# Altium schematic sheet cwh_frontend.SchDoc
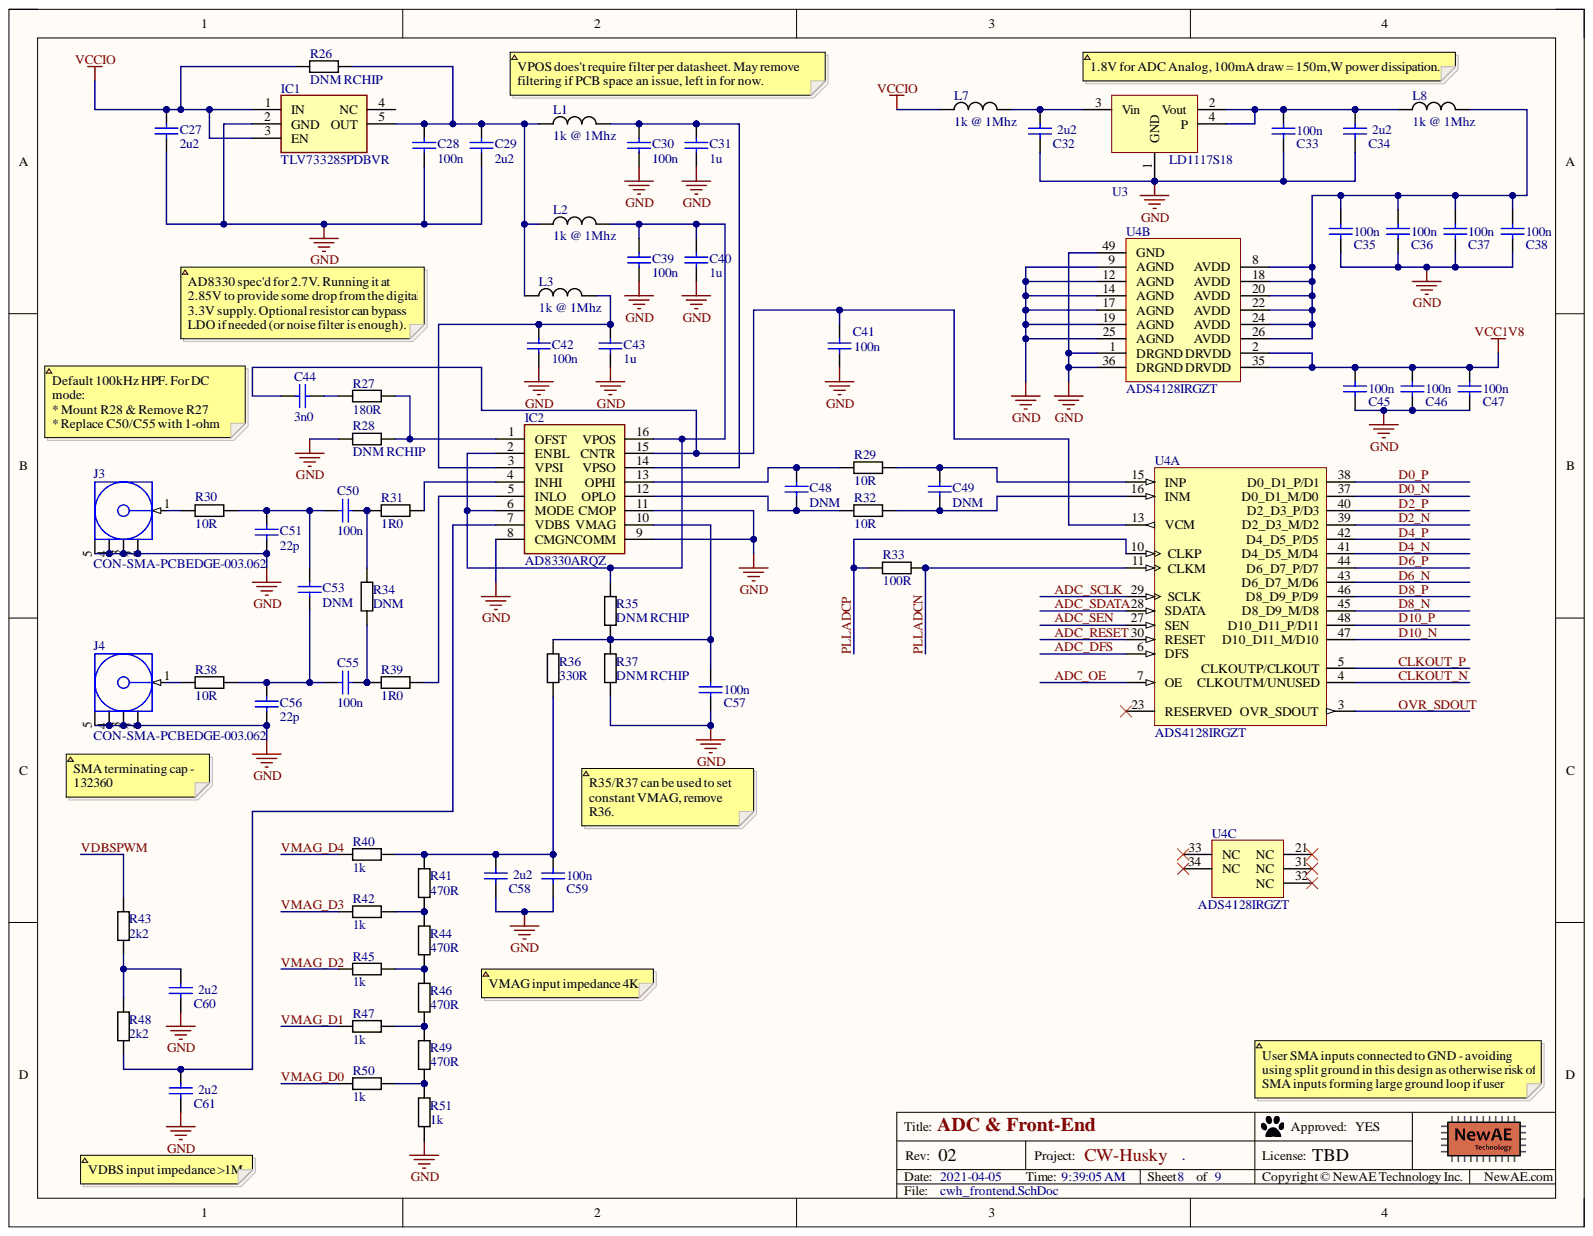
[diagram p0: cwh_frontend.SchDoc]

## Parts
C27  2u2  [0603]
C28  100n  [0402]
C29  2u2  [0603]
C30  100n  [0402]
C31  1u  [0603]
C32  2u2  [0603]
C33  100n  [0402]
C34  2u2  [0603]
C35  100n  [0402]
C36  100n  [0402]
C37  100n  [0402]
C38  100n  [0402]
C39  100n  [0402]
C40  1u  [0603]
C41  100n  [0402]
C42  100n  [0402]
C43  1u  [0603]
C44  3n0  [0603]
C45  100n  [0402]
C46  100n  [0402]
C47  100n  [0402]
C48  DNM  [0402]
C49  DNM  [0402]
C50  100n  [0402]
C51  22p  [0603]
C53  DNM  [0603]
C55  100n  [0402]
C56  22p  [0603]
C57  100n  [0402]
C58  2u2  [0603]
C59  100n  [0402]
C60  2u2  [0603]
C61  2u2  [0603]
IC1  TLV733285PDBVR  [SOT95P280X145-5N]
IC2  AD8330ARQZ  [SOP64P600X175-16N]
J3  CON-SMA-PCBEDGE-003.062  [CON-SMAM-PCB-EDGE062]
J4  CON-SMA-PCBEDGE-003.062  [CON-SMAM-PCB-EDGE062]
L1  1k @ 1Mhz  [0603]
L2  1k @ 1Mhz  [0603]
L3  1k @ 1Mhz  [0603]
L7  1k @ 1Mhz  [0603]
L8  1k @ 1Mhz  [0603]
R26  DNM RCHIP  [0603]
R27  180R  [0603]
R28  DNM RCHIP  [0603]
R29  10R  [0402]
R30  10R  [0603]
R31  1R0  [0402]
R32  10R  [0402]
R33  100R  [0402]
R34  DNM  [0402]
R35  DNM RCHIP  [0603]
R36  330R  [0603]
R37  DNM RCHIP  [0603]
R38  10R  [0603]
R39  1R0  [0402]
R40  1k  [0402]
R41  470R  [0402]
R42  1k  [0402]
R43  2k2  [0402]
R44  470R  [0402]
R45  1k  [0402]
R46  470R  [0402]
R47  1k  [0402]
R48  2k2  [0402]
R49  470R  [0402]
R50  1k  [0402]
R51  1k  [0402]
U3  LD1117S18  [SOT-223]
U4  ADS4128IRGZT  [TI-RGZ48-5600X5600TP_V]  (parts A,B,C)

## Nets
GND: C27.2 C28.2 C29.2 C30.2 C31.2 C32.2 C33.2 C34.2 C35.2 C36.2 C37.2 C38.2 C39.2 C40.2 C41.2 C42.2 C43.2 C45.2 C46.2 C47.2 C51.2 C56.2 C57.2 C58.2 C59.2 C60.2 C61.2 IC1.2(GND) IC2.8(CMGN) IC2.9(COMM) IC2.11(CMOP) J3.2 J3.3 J3.4 J3.5 J4.2 J4.3 J4.4 J4.5 R28.1 R37.1 R51.1 U3.1(GND) U4.1(DRGND) U4.9(AGND) U4.12(AGND) U4.14(AGND) U4.17(AGND) U4.19(AGND) U4.25(AGND) U4.36(DRGND) U4.49(GND)
VCC1V8: C45.1 C46.1 C47.1 U4.2(DRVDD) U4.35(DRVDD)
VCCIO: C27.1 IC1.1(IN) IC1.3(EN) L7.1 R26.1
ADC_DFS: U4.6(DFS)
ADC_OE: U4.7(OE)
ADC_RESET: U4.30(RESET)
ADC_SCLK: U4.29(SCLK)
ADC_SDATA: U4.28(SDATA)
ADC_SEN: U4.27(SEN)
CLKOUT_N: U4.4(CLKOUTM/UNUSED)
CLKOUT_P: U4.5(CLKOUTP/CLKOUT)
D0_N: U4.37(D0_D1_M/D0)
D0_P: U4.38(D0_D1_P/D1)
D2_N: U4.39(D2_D3_M/D2)
D2_P: U4.40(D2_D3_P/D3)
D4_N: U4.41(D4_D5_M/D4)
D4_P: U4.42(D4_D5_P/D5)
D6_N: U4.43(D6_D7_M/D6)
D6_P: U4.44(D6_D7_P/D7)
D8_N: U4.45(D8_D9_M/D8)
D8_P: U4.46(D8_D9_P/D9)
D10_N: U4.47(D10_D11_M/D10)
D10_P: U4.48(D10_D11_P/D11)
OVR_SDOUT: U4.3(OVR_SDOUT)
PLLADCN: R33.2 U4.11(CLKM)
PLLADCP: R33.1 U4.10(CLKP)
VDBSPWM: R43.1
VMAG_D0: R50.1
VMAG_D1: R47.1
VMAG_D2: R45.1
VMAG_D3: R42.1
VMAG_D4: R40.1
NetC28_1: C28.1 C29.1 IC1.5(OUT) L1.1 L2.1 L3.1 R26.2
NetC30_1: C30.1 C31.1 IC2.14(VPSO) L1.2
NetC32_1: C32.1 L7.2 U3.3(Vin)
NetC33_1: C33.1 C34.1 L8.1 U3.2(Vout) U3.4(P)
NetC35_1: C35.1 C36.1 C37.1 C38.1 L8.2 U4.8(AVDD) U4.18(AVDD) U4.20(AVDD) U4.22(AVDD) U4.24(AVDD) U4.26(AVDD)
NetC39_1: C39.1 C40.1 IC2.2(ENBL) IC2.6(MODE) IC2.16(VPOS) L2.2 R35.2
NetC41_1: C41.1 C44.1 IC2.15(CNTR) U4.13(VCM)
NetC42_1: C42.1 C43.1 IC2.3(VPSI) L3.2
NetC44_2: C44.2 R27.1
NetC48_1: C48.1 IC2.13(OPHI) R29.1
NetC48_2: C48.2 IC2.12(OPLO) R32.1
NetC49_1: C49.1 R29.2 U4.15(INP)
NetC49_2: C49.2 R32.2 U4.16(INM)
NetC50_1: C50.1 C51.1 C53.1 R30.2
NetC50_2: C50.2 R31.1 R34.2
NetC53_2: C53.2 C55.1 C56.1 R38.2
NetC55_2: C55.2 R34.1 R39.1
NetC57_1: C57.1 IC2.10(VMAG) R35.1 R36.2 R37.2
NetC58_1: C58.1 C59.1 R36.1 R40.2 R41.2
NetC60_1: C60.1 R43.2 R48.1
NetC61_1: C61.1 IC2.7(VDBS) R48.2
NetIC2_1: IC2.1(OFST) R27.2 R28.2
NetIC2_4: IC2.4(INHI) R31.2
NetIC2_5: IC2.5(INLO) R39.2
NetJ3_1: J3.1 R30.1
NetJ4_1: J4.1 R38.1
NetR41_1: R41.1 R42.2 R44.2
NetR44_1: R44.1 R45.2 R46.2
NetR46_1: R46.1 R47.2 R49.2
NetR49_1: R49.1 R50.2 R51.2

Not connected: IC1 4(NC); U4 21(NC) 23(RESERVED) 31(NC) 32(NC) 33(NC) 34(NC)

## Library data of the parts
C27 C29 C32 C34 C58 C60 C61 = CCHIP-2u2-0603: 2u2, 0603; Manufacture 1: Samsung; Manufacture Part Number 1: CL10B225KP8NNNC; Case-Metric: 1608; Component Group: Capacitor; Database Table Name: main; Rated Voltage: 10VDC; Case-EIA: 0603; Component Kind: Standard; Component Type: Standard; Library Name: NewAE_AltiumLib.DBLib; Mounting Technology: SMT; Part Number: CCHIP-2u2-0603; Pin Count: 2; Supplier 1: Digi-Key; Supplier Part Number 1: 1276-1134-2-ND; Value: 2u2
C28 C30 C33 C35-C39 C41 C42 C45-C47 C50 C55 C57 C59 = CCHIP-100n-0402: 100n, 0402; Manufacture Part Number 1: CGA2B3X7R1V104K050BB; Component Group: Capacitor; Database Table Name: main; Case-EIA: 0402; Component Kind: Standard; Component Type: Standard; Library Name: NewAE_AltiumLib.DBLib; Mounting Technology: SMT; Part Number: CCHIP-100n-0402; Pin Count: 2; Supplier 1: Digi-Key; Supplier Part Number 1: 445-6901-1-ND; Value: 100n
C31 C40 C43 = CCHIP-1u-0603: 1u, 0603, 50V; Manufacture Part Number 1: CL10A105KB8NNNC; Case-Metric: 1608; Component Group: Capacitor; Database Table Name: main; Rated Voltage: 50VDC; Case-EIA: 0603; Component Kind: Standard; Component Type: Standard; Library Name: NewAE_AltiumLib.DBLib; Mounting Technology: SMT; Part Number: CCHIP-1u-0603; Pin Count: 2; Supplier 1: Digi-Key; Supplier Part Number 1: 1276-1860-1-ND; Value: 1u
C44 = CCHIP-3n0-0603: 3n0, 0603; Case-Metric: 1608; Component Group: Capacitor; Database Table Name: main; Case-EIA: 0603; Component Kind: Standard; Component Type: Standard; Library Name: NewAE_AltiumLib.DBLib; Mounting Technology: SMT; Part Number: CCHIP-3n0-0603; Pin Count: 2; Value: 3n0
C48 C49 = CCHIP-DNM-0402: DNM, 0402; Component Group: Capacitor; Database Table Name: main; Case-EIA: 0402; Component Kind: Standard; Component Type: Standard; Library Name: NewAE_AltiumLib.DBLib; Mounting Technology: SMT; Part Number: CCHIP-DNM-0402; Pin Count: 2; Value: DNM
C51 C56 = CCHIP-22p-0603: 22p, 0603; Manufacture 1: Murata Electronics North America; Manufacture Part Number 1: GQM1885C1H220JB01D; Case-Metric: 1608; Component Group: Capacitor; Database Table Name: main; Rated Voltage: 50V; Case-EIA: 0603; Component Kind: Standard; Component Type: Standard; Library Name: NewAE_AltiumLib.DBLib; Mounting Technology: SMT; Part Number: CCHIP-22p-0603; Pin Count: 2; RoHS: Yes; Supplier 1: Digi-Key; Supplier Part Number 1: 490-3575-1-ND; Value: 22p
C53 = CCHIP-DNM-0603: DNM, 0603; Component Group: Capacitor; Database Table Name: main; Case-EIA: 0603; Component Kind: Standard; Component Type: Standard; Library Name: NewAE_AltiumLib.DBLib; Mounting Technology: SMT; Part Number: CCHIP-DNM-0603; Pin Count: 2; Value: DNM
IC1 = TLV733285PDBVR: Integrated Circuit; Manufacturer_Name: Texas Instruments; Arrow Part Number: TLV733285PDBVR; Arrow Price/Stock: https://www.arrow.com/en/products/tlv733285pdbvr/texas-instruments; Component Kind: Standard; Datasheet Link: http://www.ti.com/lit/gpn/tlv733p; Height: 1.45mm; Manufacturer_Part_Number: TLV733285PDBVR; Mouser Part Number: 595-TLV733285PDBVR; Mouser Price/Stock: https://www.mouser.com/Search/Refine.aspx?Keyword=595-TLV733285PDBVR
IC2 = AD8330ARQZ: Integrated Circuit; Manufacturer_Name: Analog Devices; Arrow Part Number: AD8330ARQZ; Arrow Price/Stock: https://www.arrow.com/en/products/ad8330arqz/analog-devices; Component Kind: Standard; Datasheet Link: http://componentsearchengine.com/Datasheets/3/AD8330ARQZ.pdf; Height: 1.75mm; Manufacturer_Part_Number: AD8330ARQZ; Mouser Part Number: 584-AD8330ARQZ; Mouser Price/Stock: https://www.mouser.co.uk/ProductDetail/Analog-Devices/AD8330ARQZ/?qs=%2FtpEQrCGXCy%252BhrhgNF8PFQ%3D%3D
J3 J4 = CON-SMA-PCBEDGE-003.062: SMA Female Edge Mount Connector for 0.062" Thick Board; Database Table Name: main; Component Group: Connector; Component Kind: Standard; Component Type: Standard; ComponentLink1Description: Manufacturer URL; ComponentLink1URL: http://www.linxtechnologies.com/; ComponentLink2Description: Datasheet; ComponentLink2URL: http://www.connectorcity.com/diagrams/SMA/CONSMA003.062.pdf; Library Name: NewAE_AltiumLib.DBLib; Part Number: CON-SMA-PCBEDGE-003.062; Pin Count: 5
L1-L3 L7 L8 = FBCHIP-1k-0603: Ferrite Beads HI CUR CHIP BD 0603 1000OHMS 25%; Manufacture 1: Taiyo Yuden; Manufacture Part Number 1: FBMH1608HM102-T FBMH1608HM102-T FBMH1608HM102-T FBMH1608HM102-T; Component Group: Inductor; Database Table Name: main; PP Material Stack: 0; Component Kind: Standard; Component Type: Standard; Library Name: NewAE_AltiumLib.DBLib; Mounting Technology: SMT; Part Number: FBCHIP-1k-0603; Pin Count: 2; PP Rotation: 0; RoHS: Yes; Supplier 1: Mouser; Supplier Part Number 1: 963-FBMH1608HM102-T
R26 R28 R35 R37 = RCHIP-DNM-0603: Do Not Mount Resistor; Case-Metric: 1608; Database Table Name: main; Case-EIA: 0603; Component Group: Resistor; Component Kind: Standard; Component Type: Standard; Library Name: NewAE_AltiumLib.DBLib; Mounting Technology: SMT; Part Number: RCHIP-DNM-0603; Pin Count: 2
R27 = RCHIP-180R-0603: 180R res, 0603, 1%, 1/10W; Manufacture 1: Yageo; Manufacture Part Number 1: RC0603FR-07180RL; Case-Metric: 1608; Component Group: Resistor; Database Table Name: main; Rated Power: 0.1W; Rated Voltage: 75VDC; Case-EIA: 0603; Component Kind: Standard; Component Type: Standard; Library Name: NewAE_AltiumLib.DBLib; Mounting Technology: SMT; Part Number: RCHIP-180R-0603; Pin Count: 2; Supplier 1: Digi-Key; Supplier Part Number 1: 311-180HRCT-ND; Temperature Range: -55C to 155C; Tolerance: 1%; Value: 180R
R29 R32 = RCHIP-10R-0402: 10R res, 0402, 1%, 1/16W; Manufacture 1: Yageo; Manufacture Part Number 1: RC0402FR-0710RL; Case-Metric: 1005; Component Group: Resistor; Database Table Name: main; Rated Power: 0.063W; Rated Voltage: 75VDC; Case-EIA: 0402; Component Kind: Standard; Component Type: Standard; Library Name: NewAE_AltiumLib.DBLib; Mounting Technology: SMT; Part Number: RCHIP-10R-0402; Pin Count: 2; Supplier 1: Digi-Key; Supplier Part Number 1: 311-10.0LRCT-ND; Temperature Range: -55C to 155C; Tolerance: 1%; Value: 10R
R30 R38 = RCHIP-10R-0603: 10R res, 0603, 1%, 1/10W; Manufacture 1: Yageo; Manufacture Part Number 1: RC0603FR-0710RL; Case-Metric: 1608; Component Group: Resistor; Database Table Name: main; Rated Power: 0.1W; Rated Voltage: 75VDC; Case-EIA: 0603; Component Kind: Standard; Component Type: Standard; Library Name: NewAE_AltiumLib.DBLib; Mounting Technology: SMT; Part Number: RCHIP-10R-0603; Pin Count: 2; Supplier 1: Digi-Key; Supplier Part Number 1: 311-10.0HRCT-ND; Temperature Range: -55C to 155C; Tolerance: 1%; Value: 10R
R31 R39 = RCHIP-1R0-0402: 1R0 res, 0402, 1%, 1/16W; Manufacture 1: Yageo; Manufacture Part Number 1: RC0402FR-071RL; Case-Metric: 1005; Component Group: Resistor; Database Table Name: main; Rated Power: 0.063W; Rated Voltage: 75VDC; Case-EIA: 0402; Component Kind: Standard; Component Type: Standard; Library Name: NewAE_AltiumLib.DBLib; Mounting Technology: SMT; Part Number: RCHIP-1R0-0402; Pin Count: 2; Supplier 1: Digi-Key; Supplier Part Number 1: 311-1.00LRCT-ND; Temperature Range: -55C to 155C; Tolerance: 1%; Value: 1R0
R33 = RCHIP-100R-0402: 100R res, 0402, 1%, 1/16W; Manufacture 1: Yageo; Manufacture Part Number 1: RC0402FR-07100RL; Case-Metric: 1005; Component Group: Resistor; Database Table Name: main; Rated Power: 0.063W; Rated Voltage: 75VDC; Case-EIA: 0402; Component Kind: Standard; Component Type: Standard; Library Name: NewAE_AltiumLib.DBLib; Mounting Technology: SMT; Part Number: RCHIP-100R-0402; Pin Count: 2; Supplier 1: Digi-Key; Supplier Part Number 1: 311-100LRCT-ND; Temperature Range: -55C to 155C; Tolerance: 1%; Value: 100R
R34 = RCHIP-DNM-0402: Case-Metric: 1005; Component Group: Resistor; Database Table Name: main; Case-EIA: 0402; Component Kind: Standard; Component Type: Standard; Library Name: NewAE_AltiumLib.DBLib; Part Number: RCHIP-DNM-0402; Pin Count: 2; Value: DNM
R36 = RCHIP-330R-0603: 330R res, 0603, 1%, 1/10W; Manufacture 1: Yageo; Manufacture Part Number 1: RC0603FR-07330RL; Case-Metric: 1608; Component Group: Resistor; Database Table Name: main; Rated Power: 0.1W; Rated Voltage: 75VDC; Case-EIA: 0603; Component Kind: Standard; Component Type: Standard; Library Name: NewAE_AltiumLib.DBLib; Mounting Technology: SMT; Part Number: RCHIP-330R-0603; Pin Count: 2; Supplier 1: Digi-Key; Supplier Part Number 1: 311-330HRCT-ND; Temperature Range: -55C to 155C; Tolerance: 1%; Value: 330R
R40 R42 R45 R47 R50 R51 = RCHIP-1K-0402: 1k res, 0402, 1%, 1/16W; Manufacture 1: Yageo; Manufacture Part Number 1: RC0402FR-071KL; Case-Metric: 1005; Component Group: Resistor; Database Table Name: main; Rated Power: 0.063W; Rated Voltage: 75VDC; Case-EIA: 0402; Component Kind: Standard; Component Type: Standard; Library Name: NewAE_AltiumLib.DBLib; Mounting Technology: SMT; Part Number: RCHIP-1K-0402; Pin Count: 2; Supplier 1: Digi-Key; Supplier Part Number 1: 311-1.00KLRCT-ND; Temperature Range: -55C to 155C; Tolerance: 1%; Value: 1k
R41 R44 R46 R49 = RCHIP-470R-0402: 470R res, 0402, 1%, 1/16W; Manufacture 1: Yageo; Manufacture Part Number 1: RC0402FR-07470RL; Case-Metric: 1005; Component Group: Resistor; Database Table Name: main; Rated Power: 0.063W; Rated Voltage: 75VDC; Case-EIA: 0402; Component Kind: Standard; Component Type: Standard; Library Name: NewAE_AltiumLib.DBLib; Mounting Technology: SMT; Part Number: RCHIP-470R-0402; Pin Count: 2; Supplier 1: Digi-Key; Supplier Part Number 1: 311-470LRCT-ND; Temperature Range: -55C to 155C; Tolerance: 1%; Value: 470R
R43 R48 = RCHIP-2K2-0402: 2k2 res, 0402, 1%, 1/16W; Manufacture 1: Yageo; Manufacture Part Number 1: RC0402FR-072K2L; Case-Metric: 1005; Component Group: Resistor; Database Table Name: main; Rated Power: 0.063W; Rated Voltage: 75VDC; Case-EIA: 0402; Component Kind: Standard; Component Type: Standard; Library Name: NewAE_AltiumLib.DBLib; Mounting Technology: SMT; Part Number: RCHIP-2K2-0402; Pin Count: 2; Supplier 1: Digi-Key; Supplier Part Number 1: 311-2.20KLRCT-ND; Temperature Range: -55C to 155C; Tolerance: 1%; Value: 2k2
U3 = LD1117S18: IC REG LIN POS 1.8V 800MA SOT223; Manufacture 1: STMicroelectronics; Manufacture Part Number 1: LD1117S18TR; Component Group: Analog IC; Database Table Name: main; PP Material Stack: 0; Rated Voltage: 15V; Component Kind: Standard; Component Type: Standard; Library Name: NewAE_AltiumLib.DBLib; Mounting Technology: SMT; Part Number: LD1117S18; Pin Count: 3; PP Rotation: 0; RoHS: Yes; Supplier 1: Digi-Key; Supplier Part Number 1: 497-7308-1-ND
U4 = CMP-0003-00927-1: 12-Bit, 200 MSPS, Ultralow-Power ADC, -40 to 85 degC, 48-pin QFN (RGZ48), Green (RoHS & no Sb/Br); Manufacturer: Texas Instruments; # Input Channels: 1; Analog Voltage AV/DD(Max)(V): 1.9; Analog Voltage AV/DD(Min)(V): 1.7; Architecture: Pipeline; ENOB(Bits): 11.2; INL(Max)(+/-LSB): 5; Interface: Parallel CMOS, Parallel LVDS; Resolution(Bits): 12; Sample Rate(max)(SPS): 200M; SFDR(dB): 85; SINAD(dB): 68.8; SNR(dB): 69; SubFamily: High Speed ADC (>10MSPS); Code_JEDEC: MO-220; Component Kind: Standard; ComponentLink1Description: Manufacturer URL; ComponentLink1URL: http://www.ti.com/; ComponentLink2Description: Datasheet; ComponentLink2URL: http://www.ti.com/lit/ds/symlink/ads4128.pdf; ComponentLink3Description: Package Specification; ComponentLink3URL: http://www.ti.com/lit/ml/mpqf123e/mpqf123e.pdf; DatasheetVersion: SBAS578; Digital Supply(Max)(V): 1.9; Digital Supply(Min)(V): 1.7; Eco-Plan: Green (RoHS & no Sb/Br); Library Name: Altium Content Vault; Mounting Technology: Surface Mount; PackageDescription: 48-Lead, Plastic Flat Pack, Body Size 7 x 7 mm, Pitch 0.5 mm; PackageReference: RGZ48-5600X5600TP; PackageVersion: 4204101/F, 06/2011; PartNumber: ADS4128IRGZT
